# Altium schematic sheet MeasurementCard.SchDoc(MeasurementCard)
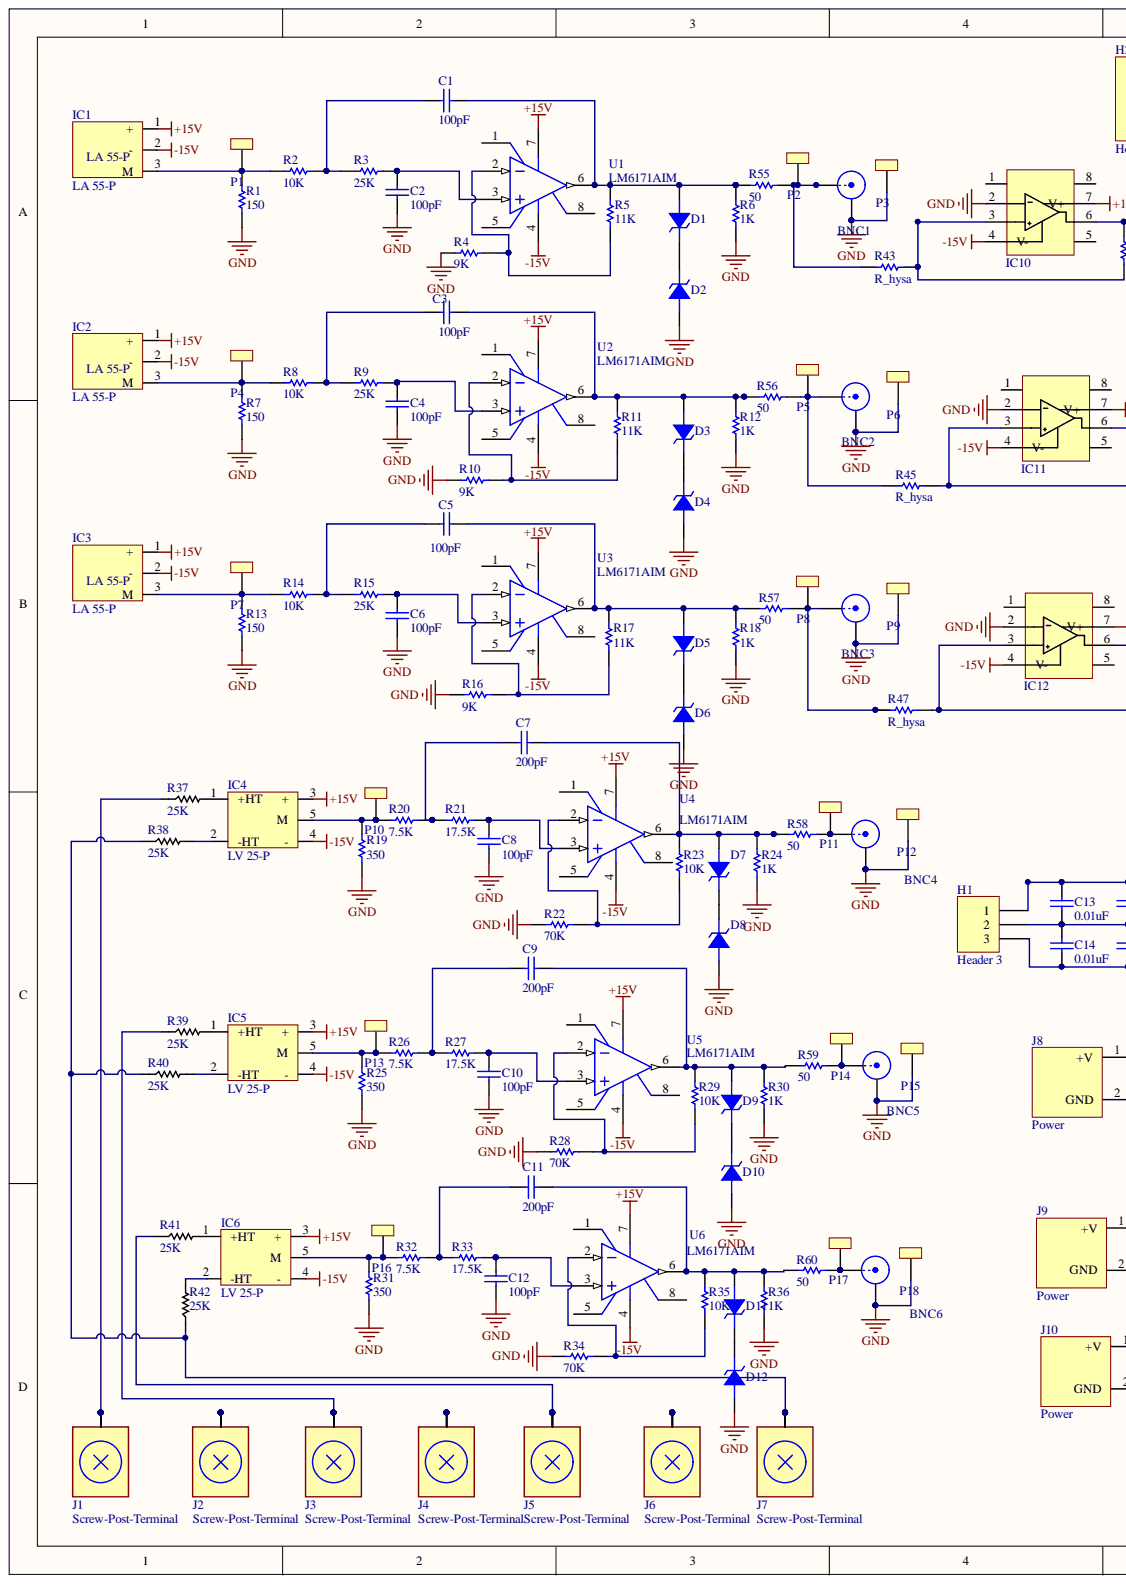
[diagram p0: MeasurementCard.SchDoc, left]
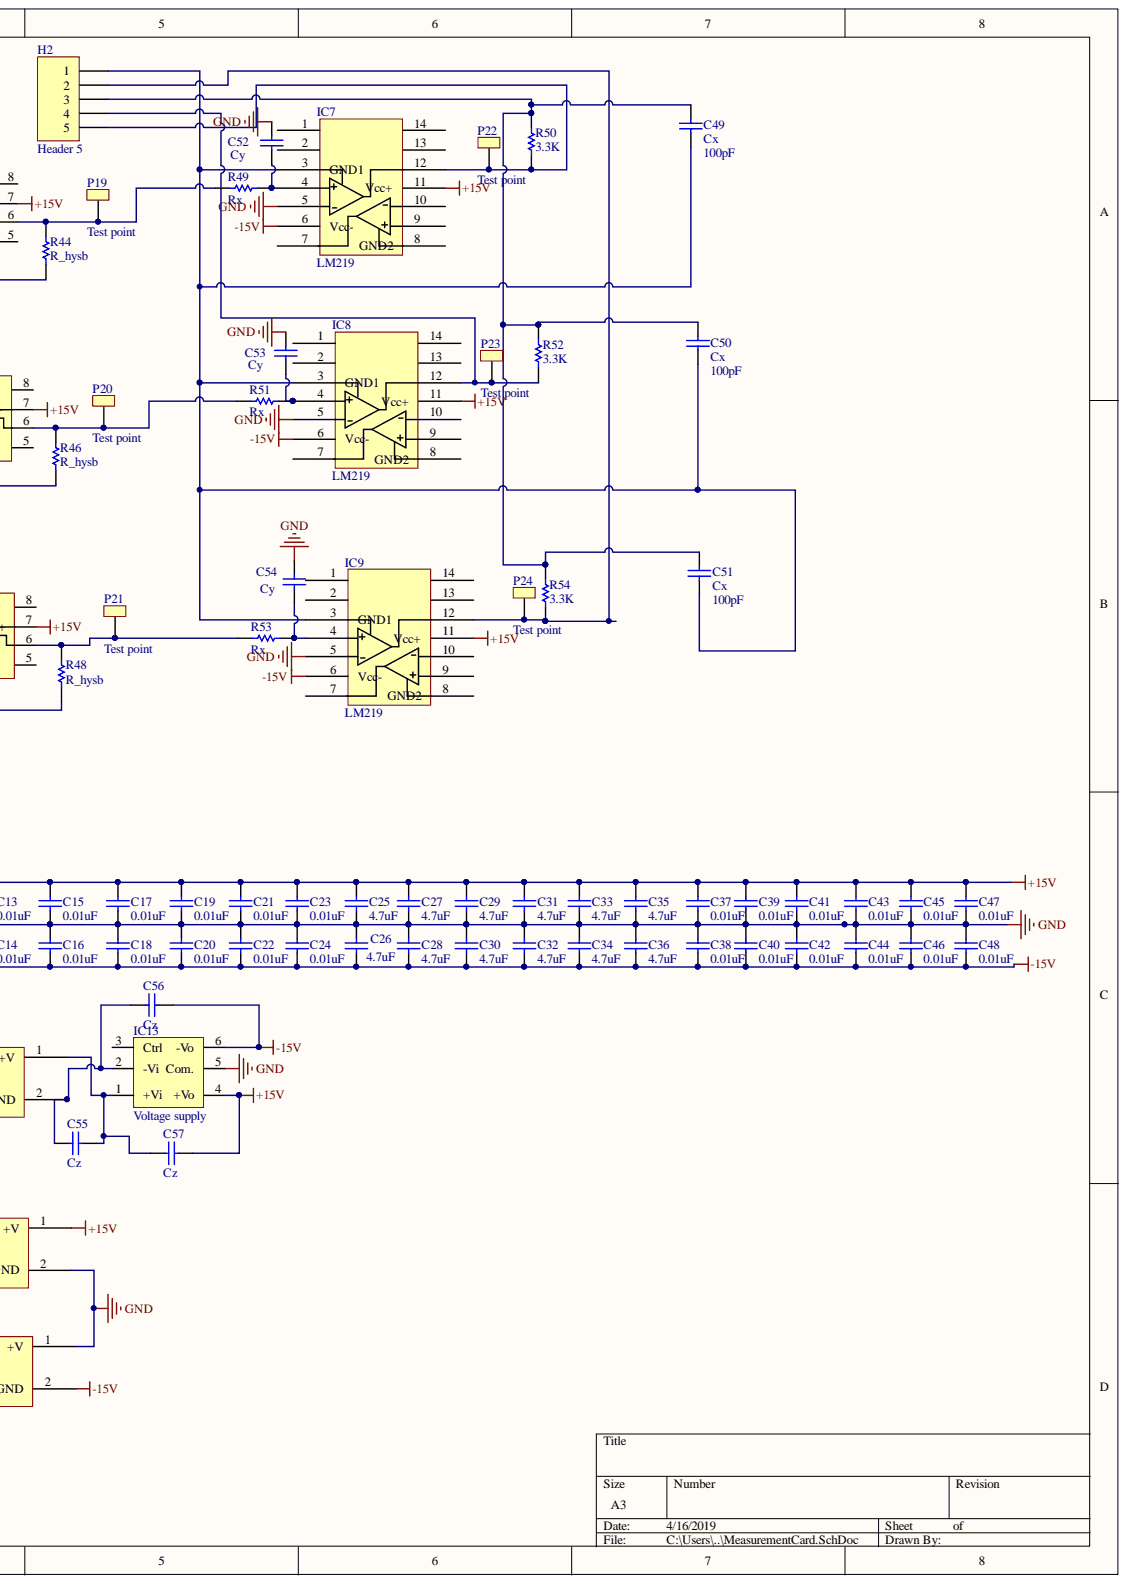
[diagram p1: MeasurementCard.SchDoc, right]

## Parts
BNC1  BNC  [BNC_F]
BNC2  BNC  [BNC_F]
BNC3  BNC  [BNC_F]
BNC4  BNC  [BNC_F]
BNC5  BNC  [BNC_F]
BNC6  BNC  [BNC_F]
C1  100pF  [0805]
C2  100pF  [0805]
C3  100pF  [0805]
C4  100pF  [0805]
C5  100pF  [0805]
C6  100pF  [0805]
C7  200pF  [0805]
C8  100pF  [0805]
C9  200pF  [0805]
C10  100pF  [0805]
C11  200pF  [0805]
C12  100pF  [0805]
C13  0.01uF  [0805]
C14  0.01uF  [0805]
C15  0.01uF  [0805]
C16  0.01uF  [0805]
C17  0.01uF  [0805]
C18  0.01uF  [0805]
C19  0.01uF  [0805]
C20  0.01uF  [0805]
C21  0.01uF  [0805]
C22  0.01uF  [0805]
C23  0.01uF  [0805]
C24  0.01uF  [0805]
C25  4.7uF  [0805]
C26  4.7uF  [0805]
C27  4.7uF  [0805]
C28  4.7uF  [0805]
C29  4.7uF  [0805]
C30  4.7uF  [0805]
C31  4.7uF  [0805]
C32  4.7uF  [0805]
C33  4.7uF  [0805]
C34  4.7uF  [0805]
C35  4.7uF  [0805]
C36  4.7uF  [0805]
C37  0.01uF  [0805]
C38  0.01uF  [0805]
C39  0.01uF  [0805]
C40  0.01uF  [0805]
C41  0.01uF  [0805]
C42  0.01uF  [0805]
C43  0.01uF  [0805]
C44  0.01uF  [0805]
C45  0.01uF  [0805]
C46  0.01uF  [0805]
C47  0.01uF  [0805]
C48  0.01uF  [0805]
C49  Cx  value 100pF  [0805]
C50  Cx  value 100pF  [0805]
C51  Cx  value 100pF  [0805]
C52  Cy  [0805]
C53  Cy  [0805]
C54  Cy  [0805]
C55  Cz  [C1808]
C56  Cz  [C1812]
C57  Cz  [C1812]
D1  Zener Diode  [Zener]  (value not drawn)
D2  Zener Diode  [Zener]  (value not drawn)
D3  Zener Diode  [Zener]  (value not drawn)
D4  Zener Diode  [Zener]  (value not drawn)
D5  Zener Diode  [Zener]  (value not drawn)
D6  Zener Diode  [Zener]  (value not drawn)
D7  Zener Diode  [Zener]  (value not drawn)
D8  Zener Diode  [Zener]  (value not drawn)
D9  Zener Diode  [Zener]  (value not drawn)
D10  Zener Diode  [Zener]  (value not drawn)
D11  Zener Diode  [Zener]  (value not drawn)
D12  Zener Diode  [Zener]  (value not drawn)
H1  Header 3  [HDR1X3]
H2  Header 5  [HDR1X5]
IC1  LA 55-P  [LA-55P]
IC2  LA 55-P  [LA-55P]
IC3  LA 55-P  [LA-55P]
IC4  LV 25-P  [LV 25-P]
IC5  LV 25-P  [LV 25-P]
IC6  LV 25-P  [LV 25-P]
IC7  LM219  [SOIC127P600-14N]
IC8  LM219  [SOIC127P600-14N]
IC9  LM219  [SOIC127P600-14N]
IC10  LEM49713  [SOIC8]  (value not drawn)
IC11  LEM49713  [SOIC8]  (value not drawn)
IC12  LEM49713  [SOIC8]  (value not drawn)
IC13  Voltage supply  [Voltage supply]
J1  Screw-Post-Terminal  [CON/SCREW_8196]
J2  Screw-Post-Terminal  [CON/SCREW_8196]
J3  Screw-Post-Terminal  [CON/SCREW_8196]
J4  Screw-Post-Terminal  [CON/SCREW_8196]
J5  Screw-Post-Terminal  [CON/SCREW_8196]
J6  Screw-Post-Terminal  [CON/SCREW_8196]
J7  Screw-Post-Terminal  [CON/SCREW_8196]
J8  Power  [Power_sokcet]
J9  Power  [Power_sokcet]
J10  Power  [Power_sokcet]
P1  Test point  [Test Point]
P2  Test point  [Test Point]
P3  Test point  [Test Point]
P4  Test point  [Test Point]
P5  Test point  [Test Point]
P6  Test point  [Test Point]
P7  Test point  [Test Point]
P8  Test point  [Test Point]
P9  Test point  [Test Point]
P10  Test point  [Test Point]
P11  Test point  [Test Point]
P12  Test point  [Test Point]
P13  Test point  [Test Point]
P14  Test point  [Test Point]
P15  Test point  [Test Point]
P16  Test point  [Test Point]
P17  Test point  [Test Point]
P18  Test point  [Test Point]
P19  Test point  [Test Point]
P20  Test point  [Test Point]
P21  Test point  [Test Point]
P22  Test point  [Test Point]
P23  Test point  [Test Point]
P24  Test point  [Test Point]
R1  150  [14-1210]
R2  10K  [8RB-1206]
R3  25K  [6-0805]
R4  9K  [ERA-0805]
R5  11K  [ERA-0805]
R6  1K  [6-0805]
R7  150  [14-1210]
R8  10K  [8RB-1206]
R9  25K  [6-0805]
R10  9K  [ERA-0805]
R11  11K  [ERA-0805]
R12  1K  [6-0805]
R13  150  [14-1210]
R14  10K  [8RB-1206]
R15  25K  [6-0805]
R16  9K  [ERA-0805]
R17  11K  [ERA-0805]
R18  1K  [6-0805]
R19  350  [8-1206]
R20  7.5K  [8RB-1206]
R21  17.5K  [6-0805]
R22  70K  [ERA-0805]
R23  10K  [ERA-0805]
R24  1K  [6-0805]
R25  350  [8-1206]
R26  7.5K  [8RB-1206]
R27  17.5K  [6-0805]
R28  70K  [ERA-0805]
R29  10K  [ERA-0805]
R30  1K  [6-0805]
R31  350  [8-1206]
R32  7.5K  [8RB-1206]
R33  17.5K  [6-0805]
R34  70K  [ERA-0805]
R35  10K  [ERA-0805]
R36  1K  [6-0805]
R37  25K  [Axial_5W]
R38  25K  [Axial_5W]
R39  25K  [Axial_5W]
R40  25K  [Axial_5W]
R41  25K  [Axial_5W]
R42  25K  [Axial_5W]
R43  R_hysa  [ERA-0805]
R44  R_hysb  [ERA-0805]
R45  R_hysa  [ERA-0805]
R46  R_hysb  [ERA-0805]
R47  R_hysa  [ERA-0805]
R48  R_hysb  [ERA-0805]
R49  Rx  [ERA-0805]
R50  3.3K  [ERA-0805]
R51  Rx  [ERA-0805]
R52  3.3K  [ERA-0805]
R53  Rx  [ERA-0805]
R54  3.3K  [ERA-0805]
R55  50  [ERA-0805]
R56  50  [ERA-0805]
R57  50  [ERA-0805]
R58  50  [ERA-0805]
R59  50  [ERA-0805]
R60  50  [ERA-0805]
U1  LM6171AIM  [M08A_N]
U2  LM6171AIM  [M08A_N]
U3  LM6171AIM  [M08A_N]
U4  LM6171AIM  [M08A_N]
U5  LM6171AIM  [M08A_N]
U6  LM6171AIM  [M08A_N]

## Nets
+15V: C13.2 C15.2 C17.2 C19.2 C21.2 C23.2 C25.2 C27.2 C29.2 C31.2 C33.2 C35.2 C37.2 C39.2 C41.2 C43.2 C45.2 C47.2 C57.2 H1.1 IC1.1 IC2.1 IC3.1 IC4.3(+) IC5.3(+) IC6.3(+) IC7.11(Vcc+) IC8.11(Vcc+) IC9.11(Vcc+) IC10.7(V+) IC11.7(V+) IC12.7(V+) IC13.4(+Vo) J9.1 U1.7 U2.7 U3.7 U4.7 U5.7 U6.7
-15V: C14.1 C16.1 C18.1 C20.1 C22.1 C24.1 C26.1 C28.1 C30.1 C32.1 C34.1 C36.1 C38.1 C40.1 C42.1 C44.1 C46.1 C48.1 C56.2 H1.3 IC1.2 IC2.2 IC3.2 IC4.4(-) IC5.4(-) IC6.4(-) IC7.6(Vcc-) IC8.6(Vcc-) IC9.6(Vcc-) IC10.4(V-) IC11.4(V-) IC12.4(V-) IC13.6(-Vo) J10.2 U1.4 U2.4 U3.4 U4.4 U5.4 U6.4
GND: BNC1.2 BNC2.2 BNC3.2 BNC4.2 BNC5.2 BNC6.2 C2.1 C4.2 C6.2 C8.2 C10.2 C12.2 C13.1 C14.2 C15.1 C16.2 C17.1 C18.2 C19.1 C20.2 C21.1 C22.2 C23.1 C24.2 C25.1 C26.2 C27.1 C28.2 C29.1 C30.2 C31.1 C32.2 C33.1 C34.2 C35.1 C36.2 C37.1 C38.2 C39.1 C40.2 C41.1 C42.2 C43.1 C44.2 C45.1 C46.2 C47.1 C48.2 C52.2 C53.2 C54.2 D2.1 D4.1 D6.1 D8.1 D10.1 D12.1 H1.2 IC7.5 IC8.5 IC9.5 IC10.2 IC11.2 IC12.2 IC13.5(Com.) J9.2 J10.1 P3.1 P6.1 P9.1 P12.1 P15.1 P18.1 R1.2 R4.2 R6.1 R7.2 R10.1 R12.2 R13.2 R16.1 R18.2 R19.2 R22.1 R24.2 R25.2 R28.1 R30.2 R31.2 R34.1 R36.2
NetBNC1_1: BNC1.1 P2.1 R43.1 R55.2
NetBNC2_1: BNC2.1 P5.1 R45.1 R56.2
NetBNC3_1: BNC3.1 P8.1 R47.1 R57.2
NetBNC4_1: BNC4.1 P11.1 R58.2
NetBNC5_1: BNC5.1 P14.1 R59.2
NetBNC6_1: BNC6.1 P17.1 R60.2
NetC1_1: C1.1 R2.2 R3.1
NetC1_2: C1.2 D1.1 R5.2 R6.2 R55.1 U1.6
NetC2_2: C2.2 R3.2 U1.3
NetC3_1: C3.1 R8.2 R9.1
NetC3_2: C3.2 D3.1 R11.1 R12.1 R56.1 U2.6
NetC4_1: C4.1 R9.2 U2.3
NetC5_1: C5.1 R14.2 R15.1
NetC5_2: C5.2 D5.1 R17.1 R18.1 R57.1 U3.6
NetC6_1: C6.1 R15.2 U3.3
NetC7_1: C7.1 R20.2 R21.1
NetC7_2: C7.2 D7.1 R23.1 R24.1 R58.1 U4.6
NetC8_1: C8.1 R21.2 U4.3
NetC9_1: C9.1 R26.2 R27.1
NetC9_2: C9.2 D9.1 R29.1 R30.1 R59.1 U5.6
NetC10_1: C10.1 R27.2 U5.3
NetC11_1: C11.1 R32.2 R33.1
NetC11_2: C11.2 D11.1 R35.1 R36.1 R60.1 U6.6
NetC12_1: C12.1 R33.2 U6.3
NetC49_1: C49.1 C50.1 C51.1 H2.1 IC7.3(GND1) IC8.3(GND1) IC9.3(GND1)
NetC49_2: C49.2 C50.2 C51.2 H2.3 R50.2 R52.2 R54.2
NetC52_1: C52.1 IC7.4 R49.2
NetC53_1: C53.1 IC8.4 R51.1
NetC54_1: C54.1 IC9.4 R53.1
NetC55_1: C55.1 C56.1 IC13.2(-Vi) J8.2
NetC55_2: C55.2 C57.1 IC13.1(+Vi) J8.1
NetD1_2: D1.2 D2.2
NetD3_2: D3.2 D4.2
NetD5_2: D5.2 D6.2
NetD7_2: D7.2 D8.2
NetD9_2: D9.2 D10.2
NetD11_2: D11.2 D12.2
NetH2_2: H2.2 IC9.12 P24.1 R54.1
NetH2_4: H2.4 IC8.12 P23.1 R52.1
NetH2_5: H2.5 IC7.12 P22.1 R50.1
NetIC1_3: IC1.3 P1.1 R1.1 R2.1
NetIC2_3: IC2.3 P4.1 R7.1 R8.1
NetIC3_3: IC3.3 P7.1 R13.1 R14.1
NetIC4_1: IC4.1(+HT) R37.2
NetIC4_2: IC4.2(-HT) R38.2
NetIC4_5: IC4.5(M) P10.1 R19.1 R20.1
NetIC5_1: IC5.1(+HT) R39.2
NetIC5_2: IC5.2(-HT) R40.2
NetIC5_5: IC5.5(M) P13.1 R25.1 R26.1
NetIC6_1: IC6.1(+HT) R41.2
NetIC6_2: IC6.2(-HT) R42.2
NetIC6_5: IC6.5(M) P16.1 R31.1 R32.1
NetIC10_3: IC10.3 R43.2 R44.1
NetIC10_6: IC10.6 P19.1 R44.2 R49.1
NetIC11_3: IC11.3 R45.2 R46.1
NetIC11_6: IC11.6 P20.1 R46.2 R51.2
NetIC12_3: IC12.3 R47.2 R48.1
NetIC12_6: IC12.6 P21.1 R48.2 R53.2
NetJ1_1: J1.1 J1.2 J1.3 J1.4 J1.5 J1.6 R37.1
NetJ2_1: J2.1 J2.2 J2.3 J2.4 J2.5 J2.6
NetJ3_1: J3.1 J3.2 J3.3 J3.4 J3.5 J3.6 R39.1
NetJ4_1: J4.1 J4.2 J4.3 J4.4 J4.5 J4.6
NetJ5_1: J5.1 J5.2 J5.3 J5.4 J5.5 J5.6 R41.1
NetJ6_1: J6.1 J6.2 J6.3 J6.4 J6.5 J6.6
NetJ7_1: J7.1 J7.2 J7.3 J7.4 J7.5 J7.6 R38.1 R40.1 R42.1
NetR4_1: R4.1 R5.1 U1.2
NetR10_2: R10.2 R11.2 U2.2
NetR16_2: R16.2 R17.2 U3.2
NetR22_2: R22.2 R23.2 U4.2
NetR28_2: R28.2 R29.2 U5.2
NetR34_2: R34.2 R35.2 U6.2

Not connected: IC7 1 2 7 8(GND2) 9 10 13 14; IC8 1 2 7 8(GND2) 9 10 13 14; IC9 1 2 7 8(GND2) 9 10 13 14; IC10 1 5 8; IC11 1 5 8; IC12 1 5 8; IC13 3(Ctrl); U1 1 5 8; U2 1 5 8; U3 1 5 8; U4 1 5 8; U5 1 5 8; U6 1 5 8

## Library data of the parts
BNC1-BNC6 = BNC_F: LA 55-P; Library Name: Sensor_board.SchLib; Digikey Part no.: A33798-ND; Component Kind: Standard
C1 C3 C5 C7 C9 C11 = C0805: Ceramic Chip Capacitor - Standard; Value: 1900pF; Note: Footprint corresponds to dimensions for bulk cassette packaging. This case size has tightened tolerances.; Simulation: CAP; Signal Integrity: C0805; Published: 17-Jul-2002; PackageReference: 0805; PackageDocument: Mar-2001; Library Name: KEMET Chip Capacitor.IntLib; LatestRevisionNote: Silkscreen size reduced.; LatestRevisionDate: 19-Mar-2004; DatasheetDocument: Mar-2001; ComponentLink2URL: http://www.kemet.com/kemet/web/homepage/kechome.nsf/vapubfilesname/F3102TA.pdf/$file/F3102TA.pdf; ComponentLink2Description: Datasheet; ComponentLink1URL: http://www.kemet.com/; ComponentLink1Description: Manufacturer Link; Component Kind: Standard; Code_JEITA: 0805; Code_IPC: 2012[0805]; Publisher: Altium Limited
C2 C4 C6 C8 C10 C12 = C0805: Ceramic Chip Capacitor - Standard; Value: 1000pF; Note: Footprint corresponds to dimensions for bulk cassette packaging. This case size has tightened tolerances.; Simulation: CAP; Signal Integrity: C0805; Published: 17-Jul-2002; PackageReference: 0805; PackageDocument: Mar-2001; Library Name: KEMET Chip Capacitor.IntLib; LatestRevisionNote: Silkscreen size reduced.; LatestRevisionDate: 19-Mar-2004; DatasheetDocument: Mar-2001; ComponentLink2URL: http://www.kemet.com/kemet/web/homepage/kechome.nsf/vapubfilesname/F3102TA.pdf/$file/F3102TA.pdf; ComponentLink2Description: Datasheet; ComponentLink1URL: http://www.kemet.com/; ComponentLink1Description: Manufacturer Link; Component Kind: Standard; Code_JEITA: 0805; Code_IPC: 2012[0805]; Publisher: Altium Limited
C13 C14 C17-C36 C43-C51 = C0805: Ceramic Chip Capacitor - Standard; Note: Footprint corresponds to dimensions for bulk cassette packaging. This case size has tightened tolerances.; Simulation: CAP; Signal Integrity: C0805; Published: 17-Jul-2002; PackageReference: 0805; PackageDocument: Mar-2001; Library Name: KEMET Chip Capacitor.IntLib; LatestRevisionNote: Silkscreen size reduced.; LatestRevisionDate: 19-Mar-2004; DatasheetDocument: Mar-2001; ComponentLink2URL: http://www.kemet.com/kemet/web/homepage/kechome.nsf/vapubfilesname/F3102TA.pdf/$file/F3102TA.pdf; ComponentLink2Description: Datasheet; ComponentLink1URL: http://www.kemet.com/; ComponentLink1Description: Manufacturer Link; Component Kind: Standard; Code_JEITA: 0805; Code_IPC: 2012[0805]; Publisher: Altium Limited
  C13 C14 C17-C24 C43-C48: Value: 0.01uF
  C25-C36: Value: 4.7uF
C15 C16 = C0805: Ceramic Chip Capacitor - Standard; Value: 0.01pF; Note: Footprint corresponds to dimensions for bulk cassette packaging. This case size has tightened tolerances.; Simulation: CAP; Signal Integrity: C0805; Published: 17-Jul-2002; PackageReference: 0805; PackageDocument: Mar-2001; Library Name: KEMET Chip Capacitor.IntLib; LatestRevisionNote: Silkscreen size reduced.; LatestRevisionDate: 19-Mar-2004; DatasheetDocument: Mar-2001; ComponentLink2URL: http://www.kemet.com/kemet/web/homepage/kechome.nsf/vapubfilesname/F3102TA.pdf/$file/F3102TA.pdf; ComponentLink2Description: Datasheet; ComponentLink1URL: http://www.kemet.com/; ComponentLink1Description: Manufacturer Link; Component Kind: Standard; Code_JEITA: 0805; Code_IPC: 2012[0805]; Publisher: Altium Limited
C37-C42 = C0805: Ceramic Chip Capacitor - Standard; Value: 4.7uF; Note: Footprint corresponds to dimensions for bulk cassette packaging. This case size has tightened tolerances.; Simulation: CAP; Signal Integrity: C0805; Published: 17-Jul-2002; PackageReference: 0805; PackageDocument: Mar-2001; Library Name: KEMET Chip Capacitor.IntLib; LatestRevisionNote: Silkscreen size reduced.; LatestRevisionDate: 19-Mar-2004; DatasheetDocument: Mar-2001; ComponentLink2URL: http://www.kemet.com/kemet/web/homepage/kechome.nsf/vapubfilesname/F3102TA.pdf/$file/F3102TA.pdf; ComponentLink2Description: Datasheet; ComponentLink1URL: http://www.kemet.com/; ComponentLink1Description: Manufacturer Link; Component Kind: Standard; Code_JEITA: 0805; Code_IPC: 2012[0805]; Publisher: Altium Limited
C52-C54 = C0805: Ceramic Chip Capacitor - Standard; Value: 100pF; Note: Footprint corresponds to dimensions for bulk cassette packaging. This case size has tightened tolerances.; Simulation: CAP; Signal Integrity: C0805; Published: 17-Jul-2002; PackageReference: 0805; PackageDocument: Mar-2001; Library Name: KEMET Chip Capacitor.IntLib; LatestRevisionNote: Silkscreen size reduced.; LatestRevisionDate: 19-Mar-2004; DatasheetDocument: Mar-2001; ComponentLink2URL: http://www.kemet.com/kemet/web/homepage/kechome.nsf/vapubfilesname/F3102TA.pdf/$file/F3102TA.pdf; ComponentLink2Description: Datasheet; ComponentLink1URL: http://www.kemet.com/; ComponentLink1Description: Manufacturer Link; Component Kind: Standard; Code_JEITA: 0805; Code_IPC: 2012[0805]; Publisher: Altium Limited
C55 = CDR03: Ceramic Chip Capacitor - MIL-PRF-55681; Value: 100pF; Simulation: CAP; Signal Integrity: CDR03; Published: 17-Jul-2002; PackageReference: C1808; PackageDocument: Mar-2001; Library Name: KEMET Chip Capacitor.IntLib; LatestRevisionNote: Silkscreen size reduced.; LatestRevisionDate: 19-Mar-2004; DatasheetDocument: Mar-2001; ComponentLink2URL: http://www.kemet.com/kemet/web/homepage/kechome.nsf/vapubfilesname/F3102TA.pdf/$file/F3102TA.pdf; ComponentLink2Description: Datasheet; ComponentLink1URL: http://www.kemet.com/; ComponentLink1Description: Manufacturer Link; Component Kind: Standard; Publisher: Altium Limited
C56 C57 = CDR04: Ceramic Chip Capacitor - MIL-PRF-55681; Value: 100pF; Simulation: CAP; Signal Integrity: CDR04; Published: 17-Jul-2002; PackageReference: C1812; PackageDocument: Mar-2001; Library Name: KEMET Chip Capacitor.IntLib; LatestRevisionNote: Silkscreen size reduced.; LatestRevisionDate: 19-Mar-2004; DatasheetDocument: Mar-2001; ComponentLink2URL: http://www.kemet.com/kemet/web/homepage/kechome.nsf/vapubfilesname/F3102TA.pdf/$file/F3102TA.pdf; ComponentLink2Description: Datasheet; ComponentLink1URL: http://www.kemet.com/; ComponentLink1Description: Manufacturer Link; Component Kind: Standard; Publisher: Altium Limited
H1 = Header 3: Header, 3-Pin; Signal Integrity: Connector; Library Name: Miscellaneous Connectors.IntLib; LatestRevisionNote: Re-released for DXP Platform.; LatestRevisionDate: 17-Jul-2002; Component Kind: Standard; Publisher: Altium Limited
H2 = Header 5: Header, 5-Pin; Signal Integrity: Connector; Library Name: Miscellaneous Connectors.IntLib; LatestRevisionNote: Re-released for DXP Platform.; LatestRevisionDate: 17-Jul-2002; Component Kind: Standard; Publisher: Altium Limited
IC7-IC9 = LM219: LA 55-P; Library Name: Sensor_board.SchLib; Digikey Part no.: 497-1544-1-ND; Component Kind: Standard
IC10-IC12 = LEM49713: LA 55-P; Library Name: Sensor_board.SchLib; Component Kind: Standard
J8-J10 = Power_barrel: Power male connector; igikey part no.: CP-057A-ND; Library Name: Sensor_board.SchLib; Component Kind: Standard
R1 R7 R13 = ERJ14: Thick Film Chip Resistor, 1 Ohm to 10M Ohm Range, 5% Tolerance, 1210 Size, 0.25 W; Note: If flow soldering, adjust pad width of PCB Footprint to 0.7 - 0.8 times the width of the resistor.; Simulation: RESISTOR; Signal Integrity: Res; Published: 17-Dec-2003; PackageReference: 14-1210; PackageDocument: Mar-2003; Library Name: Panasonic Resistor.IntLib; LatestRevisionNote: Silkscreen size reduced.; LatestRevisionDate: 19-Mar-2004; ComponentLink2URL: http://www.panasonic.com/industrial/components/pdf/AOA0000CE1.pdf; ComponentLink2Description: Datasheet; ComponentLink1URL: http://www.panasonic.com/industrial/components/resistive_products.htm; ComponentLink1Description: Manufacturer Link; Component Kind: Standard; Code_IPC: 3225 [1210]; Publisher: Altium Limited
R2 R8 R14 R20 R26 R32 = ERJ8BQ: Low Ohmic Value Thick Film Chip Resistor, 0.22 Ohm to 9.1 Ohm Range, 1%, 2% and 5% Tolerance, 1206 Size, 0.33 W; Simulation: RESISTOR; Signal Integrity: Res; Published: 17-Dec-2003; PackageReference: 8RB-1206; PackageDocument: Mar-2003; Library Name: Panasonic Resistor.IntLib; LatestRevisionNote: Silkscreen size reduced.; LatestRevisionDate: 19-Mar-2004; ComponentLink2URL: http://www.panasonic.com/industrial/components/pdf/AOA0000CE3.pdf; ComponentLink2Description: Datasheet; ComponentLink1URL: http://www.panasonic.com/industrial/components/resistive_products.htm; ComponentLink1Description: Manufacturer Link; Component Kind: Standard; Code_IPC: 3216 [1206]; Publisher: Altium Limited
R3 = ERJ6RB: Precision Thick Film Chip Resistor, 10 Ohm to 1M Ohm Range, 1% and 0.5% Tolerance, 0805 Size, 0.1 W; Simulation: RESISTOR; Signal Integrity: Res; Published: 17-Dec-2003; PackageReference: 6-0805; PackageDocument: Mar-2003; Library Name: Panasonic Resistor.IntLib; LatestRevisionNote: Silkscreen size reduced.; LatestRevisionDate: 19-Mar-2004; ComponentLink2URL: http://www.panasonic.com/industrial/components/pdf/AOA0000CE2.pdf; ComponentLink2Description: Datasheet; ComponentLink1URL: http://www.panasonic.com/industrial/components/resistive_products.htm; ComponentLink1Description: Manufacturer Link; Component Kind: Standard; Code_IPC: 2012 [0805]; Publisher: Altium Limited
R4 R5 R10 R11 R16 R17 R22 R23 R28 R29 R34 R35 R43-R48 R55-R60 = ERA6Y: Rectangular Thick Film Chip Resistor, 10 Ohm to 1M Ohm Range, 0.1% and 0.5% Tolerance, 0805 Size, 0.1 W; Note: If flow soldering, adjust pad width of PCB Footprint to 0.7 - 0.8 times the width of the resistor.; Simulation: RESISTOR; Signal Integrity: Res; Published: 17-Dec-2003; PackageReference: ERA-0805; PackageDocument: Mar-2003; Library Name: Panasonic Resistor.IntLib; LatestRevisionNote: Silkscreen size reduced.; LatestRevisionDate: 19-Mar-2004; ComponentLink2URL: http://www.panasonic.com/industrial/components/pdf/AOA0000CE8.pdf; ComponentLink2Description: Datasheet; ComponentLink1URL: http://www.panasonic.com/industrial/components/resistive_products.htm; ComponentLink1Description: Manufacturer Link; Component Kind: Standard; Code_IPC: 2012 [0805]; Publisher: Altium Limited
R6 R9 R12 R15 R18 = ERQF06: Flat Chip Fuse Resistor, 0.47 Ohm to 10 Ohm Range, 5% Tolerance, 0805 Size, 0.1 W; Note: If flow soldering, adjust pad width of PCB Footprint to 0.7 - 0.8 times the width of the resistor.; Simulation: RESISTOR; Signal Integrity: Res; Published: 17-Dec-2003; PackageReference: 6-0805; PackageDocument: Mar-2003; Library Name: Panasonic Resistor.IntLib; LatestRevisionNote: Silkscreen size reduced.; LatestRevisionDate: 19-Mar-2004; ComponentLink2URL: http://www.panasonic.com/industrial/components/pdf/AOB0000CE1.pdf; ComponentLink2Description: Datasheet; ComponentLink1URL: http://www.panasonic.com/industrial/components/resistive_products.htm; ComponentLink1Description: Manufacturer Link; Component Kind: Standard; Code_IPC: 2012 [0805]; Publisher: Altium Limited
R19 R25 R31 = ERJ8EN: Precision Thick Film Chip Resistor, 10 Ohm to 2.2M Ohm Range, 1% Tolerance, 1206 Size, 0.125 W; Simulation: RESISTOR; Signal Integrity: Res; Published: 17-Dec-2003; PackageReference: 8-1206; PackageDocument: Mar-2003; Library Name: Panasonic Resistor.IntLib; LatestRevisionNote: Silkscreen size reduced.; LatestRevisionDate: 19-Mar-2004; ComponentLink2URL: http://www.panasonic.com/industrial/components/pdf/AOA0000CE2.pdf; ComponentLink2Description: Datasheet; ComponentLink1URL: http://www.panasonic.com/industrial/components/resistive_products.htm; ComponentLink1Description: Manufacturer Link; Component Kind: Standard; Code_IPC: 3216 [1206]; Publisher: Altium Limited
R21 R24 R27 R30 R33 R36 = ERJ6RQ: Low Ohmic Value Thick Film Chip Resistor, 0.22 Ohm to 9.1 Ohm Range, 1%, 2% and 5% Tolerance, 0805 Size, 0.125 W; Simulation: RESISTOR; Signal Integrity: Res; Published: 17-Dec-2003; PackageReference: 6-0805; PackageDocument: Mar-2003; Library Name: Panasonic Resistor.IntLib; LatestRevisionNote: Silkscreen size reduced.; LatestRevisionDate: 19-Mar-2004; ComponentLink2URL: http://www.panasonic.com/industrial/components/pdf/AOA0000CE3.pdf; ComponentLink2Description: Datasheet; ComponentLink1URL: http://www.panasonic.com/industrial/components/resistive_products.htm; ComponentLink1Description: Manufacturer Link; Component Kind: Standard; Code_IPC: 2012 [0805]; Publisher: Altium Limited
R37-R42 = R_thru_hole: LA 55-P; Library Name: Sensor_board.SchLib; Component Kind: Standard
R49-R54 = ERAS: Metal Film Thermosensitive Chip Resistor, 0.56 Ohm to 10k Ohm Range, 5% Tolerance, 0805 Size, 0.1 W; Note: If flow soldering, adjust pad width of PCB Footprint to 0.7 - 0.8 times the width of the resistor.; Simulation: RESISTOR; Signal Integrity: Res; Published: 17-Dec-2003; PackageReference: ERA-0805; PackageDocument: Mar-2003; Library Name: Panasonic Resistor.IntLib; LatestRevisionNote: Silkscreen size reduced.; LatestRevisionDate: 19-Mar-2004; ComponentLink2URL: http://www.panasonic.com/industrial/components/pdf/AOF0000CE1.pdf; ComponentLink2Description: Datasheet; ComponentLink1URL: http://www.panasonic.com/industrial/components/resistive_products.htm; ComponentLink1Description: Manufacturer Link; Component Kind: Standard; Code_IPC: 2012 [0805]; Publisher: Altium Limited
U1-U6 = LM6171AIM: High Speed Low Power Low Distortion Voltage Feedback Amplifier; Manufacturer: National Semiconductor; PowerWise: Y; PackageTopMarking: NSZXTT*LM61*71AIM; CompType: Amplifiers; Simulation: LM6171A; RoHS: O; Published: 4-Dec-2008; PinCount: 8; PartNumber: LM6171AIM; PackageVersion: revL, Sep-2006; PackageReference: M08A; PackageDescription: SOIC Narrow, 4.9 x 3.9 x 1.45mm, 8 Lead, 1.27mm Pitch; Library Name: NSC Amplifier.IntLib; LatestRevisionNote: Sim Model Added.; LatestRevisionDate: 13-Jan-2009; DigiKeyPartNumber: LM6171AIM-ND; DatasheetVersion: Feb-2003; ComponentLink3URL: http://www.national.com/packaging/folders/m08a.html; ComponentLink3Description: M08A Package Page; ComponentLink2URL: http://www.national.com/pf/LM/LM6171.html; ComponentLink2Description: LM6171 Web Page; ComponentLink1URL: http://www.national.com/ds/LM/LM6171.pdf; ComponentLink1Description: LM6171 Datasheet; Component Kind: Standard; Code_JEDEC: MS-012-AA; Code_IPC: SOIC127P600-8; Publisher: Altium Limited
D1-D12 = Zener Diode: Library Name: Sensor_board.SchLib; Digikey Part no.: SMAZ10-TPMSCT-ND; Component Kind: Standard
IC1-IC3 = LA 55-P: Library Name: Sensor_board.SchLib; Digikey Part no.: 398-1010-ND; Description: LA 55-P; Component Kind: Standard
IC4-IC6 = LV 25-P: Library Name: Sensor_board.SchLib; Digikey Part no.: 398-1019-ND; Description: LV 25-P; Component Kind: Standard
IC13 = Voltage supply: Library Name: Sensor_board.SchLib; Digikey Part no.: 945-1276-ND; Component Kind: Standard
J1-J7 = Screw-Post-Terminal: Library Name: Sensor_board.SchLib; Digikey Part no.: 8196K-ND; Component Kind: Standard
P1-P24 = Test point: Library Name: Sensor_board.SchLib; Digikey Part no.: 5015KCT-ND; Description: Test Point; Component Kind: Standard
